# Altium schematic sheet INF_Quadrotor_V1.0.SchDoc(INF_Quadrotor_V1.0)
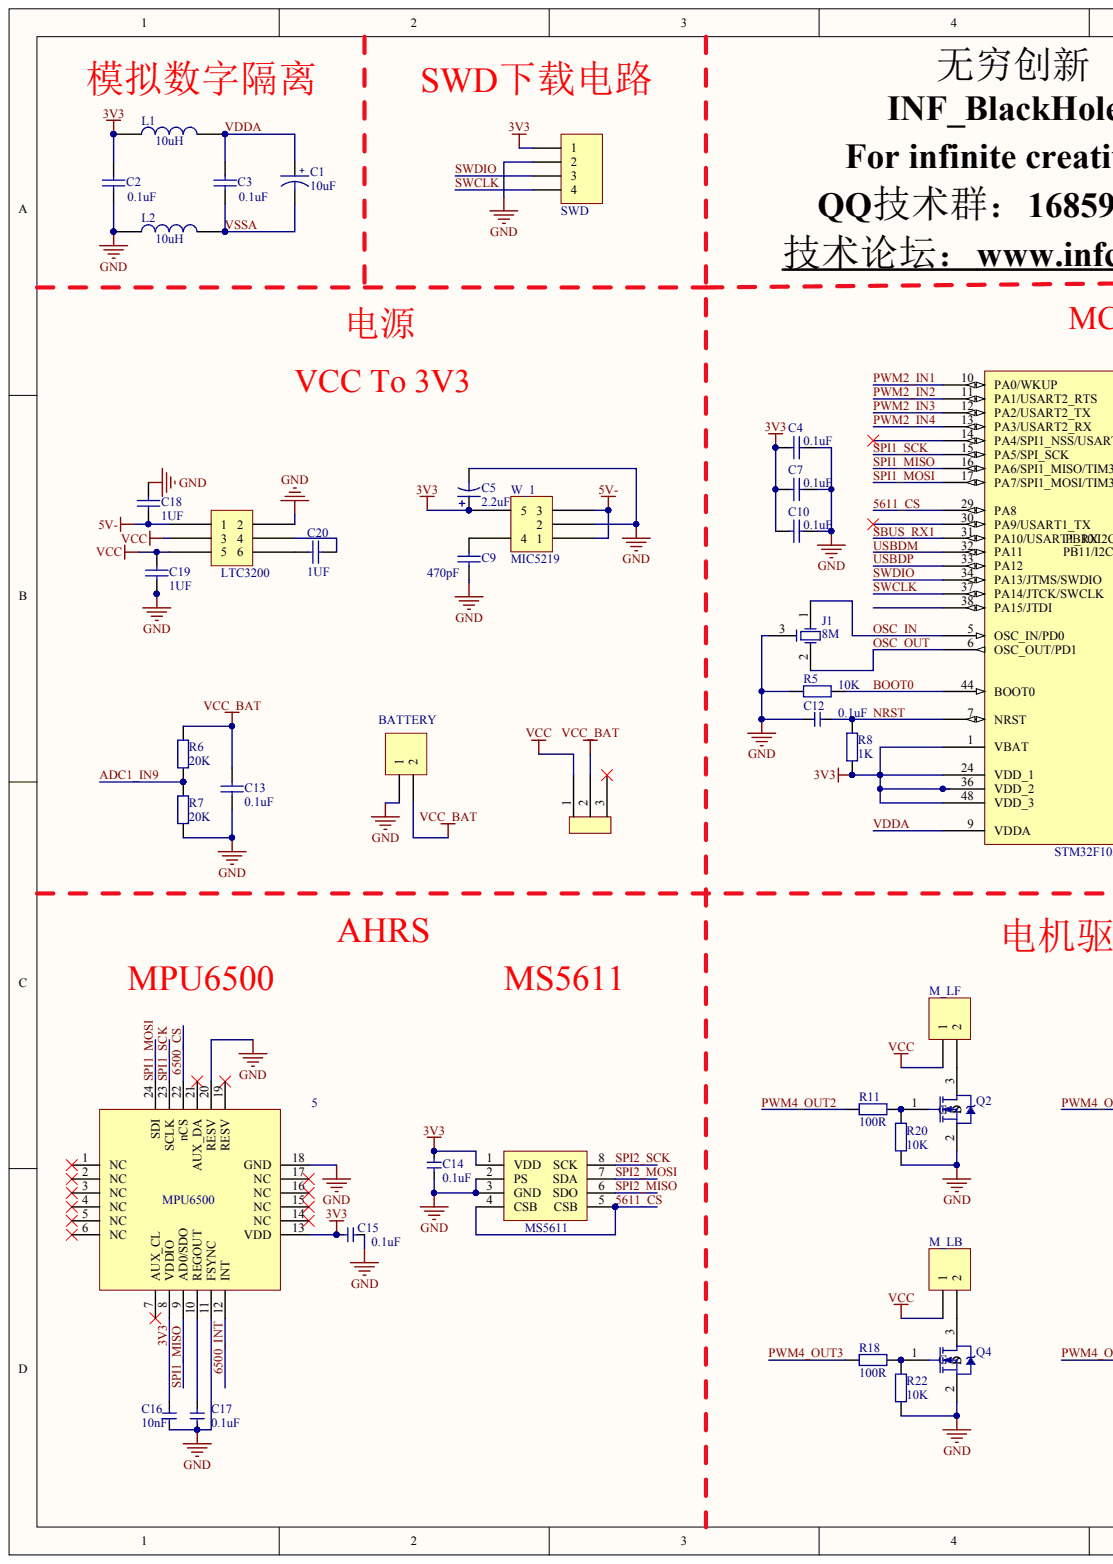
[diagram p0: INF_Quadrotor_V1.0.SchDoc, left]
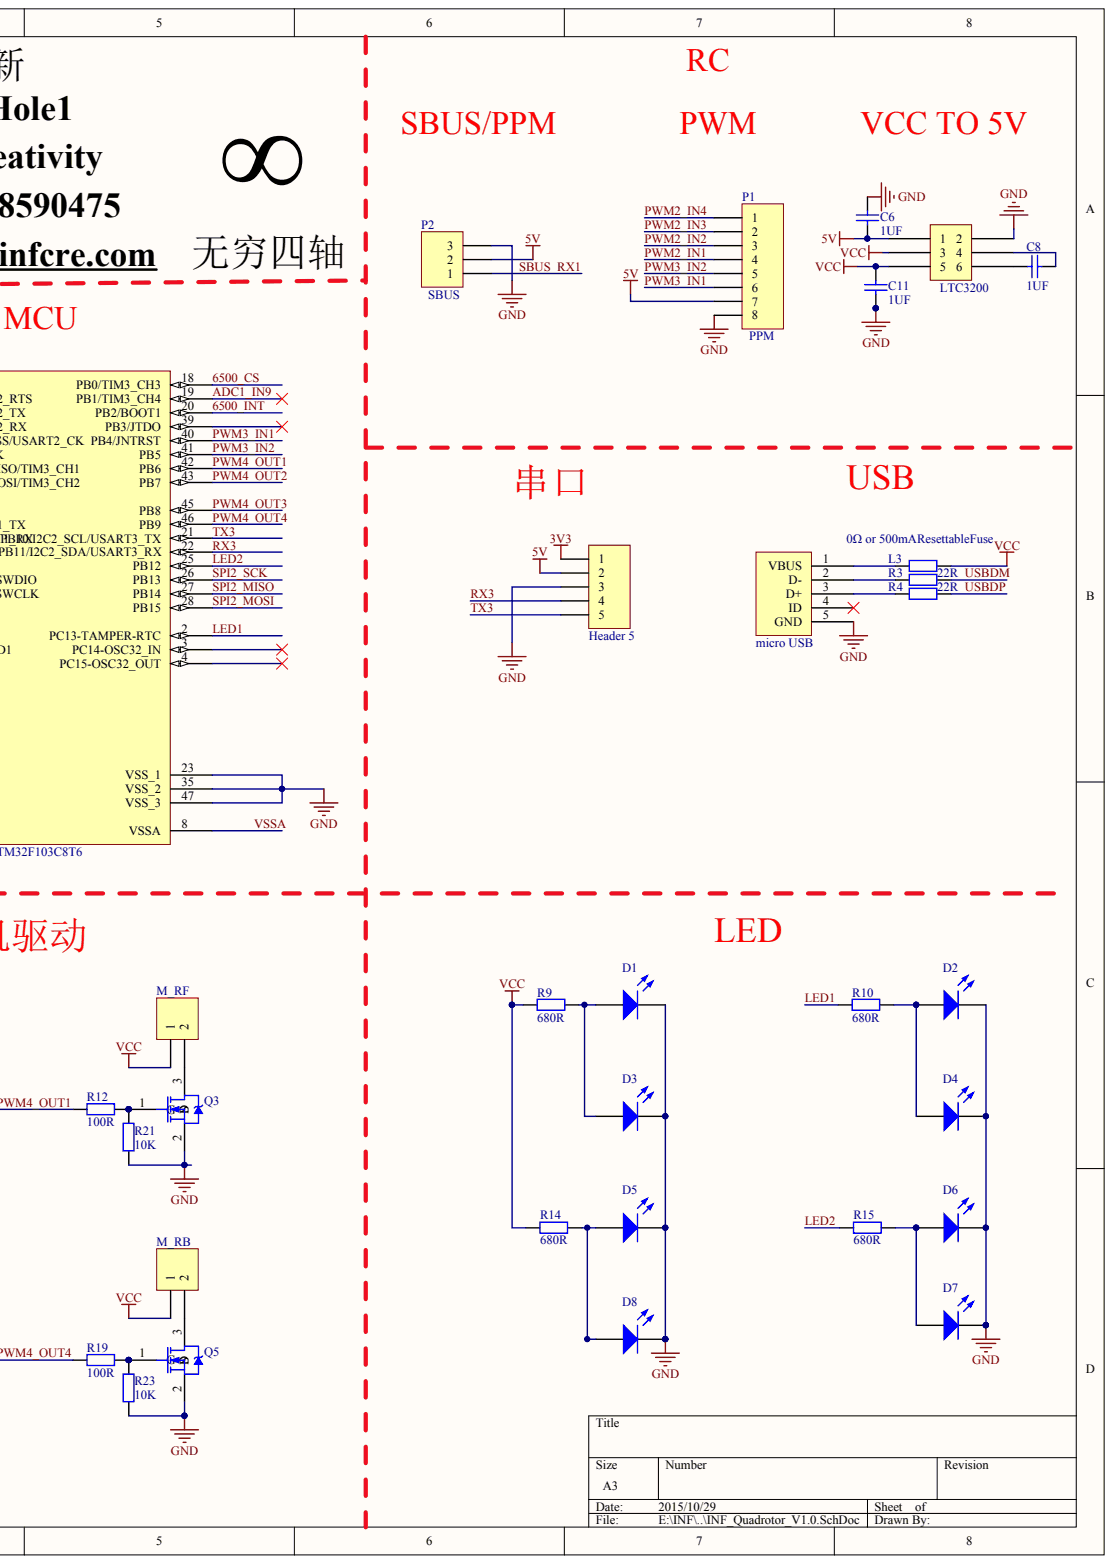
[diagram p1: INF_Quadrotor_V1.0.SchDoc, right]

## Parts
1  SWD
2  STM32F103C8T6
3  LTC3200
4  (no value)
5  MPU6500
C1  10uF
C2  value 0.1uF
C3  value 0.1uF
C4  value 0.1uF
C5  value 2.2uF  (hidden comment: Cap Pol1)
C6  value 1UF  (hidden comment: Cap)
C7  value 0.1uF
C8  value 1UF  (hidden comment: Cap)
C9  value 470pF  (hidden comment: Cap)
C10  value 0.1uF
C11  value 1UF  (hidden comment: Cap)
C12  value 0.1uF  (hidden comment: Cap_0402)
C13  value 0.1uF  (hidden comment: Cap)
C14  value 0.1uF  (hidden comment: Cap_0402)
C15  value 0.1uF  (hidden comment: Cap_0402)
C16  value 10nF  (hidden comment: 1608[0603])
C17  value 0.1uF  (hidden comment: Cap_0402)
C18  value 1UF  (hidden comment: Cap)
C19  value 1UF  (hidden comment: Cap)
C20  value 1UF  (hidden comment: Cap)
D1  LED2  (value not drawn)
D2  LED2  (value not drawn)
D3  LED2  (value not drawn)
D4  LED2  (value not drawn)
D5  LED2  (value not drawn)
D6  LED2  (value not drawn)
D7  LED2  (value not drawn)
D8  LED2  (value not drawn)
J1  8M
L1  10uH
L2  10uH
L3  value 0Ω or 500mAResettableFuse  (hidden comment: Res2)
M_LB  MHDR1X2  (value not drawn)
M_LF  MHDR1X2  (value not drawn)
M_RB  MHDR1X2  (value not drawn)
M_RF  MHDR1X2  (value not drawn)
MS1  MS5611
P1  PPM
P2  SBUS
P4  BATTERY
P?  Header 5
Q2  IRLML2502  (value not drawn)
Q3  IRLML2502  (value not drawn)
Q4  IRLML2502  (value not drawn)
Q5  IRLML2502  (value not drawn)
R3  value 22R  (hidden comment: Res2)
R4  value 22R  (hidden comment: Res2)
R5  value 10K  (hidden comment: Res2)
R6  value 20K  (hidden comment: Res2)
R7  value 20K  (hidden comment: Res2)
R8  value 1K  (hidden comment: Res2)
R9  value 680R  (hidden comment: Res2)
R10  value 680R  (hidden comment: Res2)
R11  value 100R  (hidden comment: Res2)
R12  value 100R  (hidden comment: Res2)
R14  value 680R  (hidden comment: Res2)
R15  value 680R  (hidden comment: Res2)
R18  value 100R  (hidden comment: Res2)
R19  value 100R  (hidden comment: Res2)
R20  value 10K  (hidden comment: Res2)
R21  value 10K  (hidden comment: Res2)
R22  value 10K  (hidden comment: Res2)
R23  value 10K  (hidden comment: Res2)
U1  micro USB
W_1  MIC5219
  LTC3200

## Nets
5V: 3.1 C6.1 P1.7 P2.2 P?.2
5V-: C18.1 W_1.1 W_1.3 .1
GND: 1.2 2.23(VSS_1) 2.35(VSS_2) 2.47(VSS_3) 3.2 5.11(FSYNC) 5.18(GND) 5.20(RESV) C2.1 C4.1 C5.2 C6.2 C7.1 C9.1 C10.1 C11.2 C12.1 C13.1 C14.2 C15.1 C16.1 C17.1 C18.2 C19.2 D1.2 D2.2 D3.2 D4.2 D5.2 D6.2 D7.2 D8.2 J1.3 L2.1 MS1.2(PS) MS1.3(GND) P1.8 P2.3 P4.1 P?.3 Q2.2 Q3.2 Q4.2 Q5.2 R5.1 R7.1 R20.1 R21.1 R22.1 R23.1 U1.5(GND) W_1.2 .2
Net_4: C20.2 .4
Net_6: C20.1 .6
VCC: 3.3 3.5 4.1 C11.1 C19.1 L3.1 M_LB.1 M_LF.1 M_RB.1 M_RF.1 R9.1 R14.1 .3 .5
VCC_BAT: 4.2 C13.2 P4.2 R6.1
3V3: 1.1 2.1(VBAT) 2.24(VDD_1) 2.36(VDD_2) 2.48(VDD_3) 5.8(VDDIO) 5.13(VDD) C2.2 C4.2 C5.1 C7.2 C10.2 C14.1 C15.2 C16.2 L1.1 MS1.1(VDD) P?.1 R8.1 W_1.5
5611_CS: 2.29(PA8) MS1.4(CSB) MS1.5(CSB)
6500_CS: 2.18(PB0/TIM3_CH3) 5.22(nCS)
6500_INT: 2.20(PB2/BOOT1) 5.12(INT)
ADC1_IN9: 2.19(PB1/TIM3_CH4) R6.2 R7.2
BOOT0: 2.44(BOOT0) R5.2
LED1: 2.2(PC13-TAMPER-RTC) R10.1
LED2: 2.25(PB12) R15.1
NRST: 2.7(NRST) C12.2 R8.2
OSC_IN: 2.5(OSC_IN/PD0) J1.1
OSC_OUT: 2.6(OSC_OUT/PD1) J1.2
PWM2_IN1: 2.10(PA0/WKUP) P1.4
PWM2_IN2: 2.11(PA1/USART2_RTS) P1.3
PWM2_IN3: 2.12(PA2/USART2_TX) P1.2
PWM2_IN4: 2.13(PA3/USART2_RX) P1.1
PWM3_IN1: 2.40(PB4/JNTRST) P1.6
PWM3_IN2: 2.41(PB5) P1.5
PWM4_OUT1: 2.42(PB6) R12.1
PWM4_OUT2: 2.43(PB7) R11.1
PWM4_OUT3: 2.45(PB8) R18.1
PWM4_OUT4: 2.46(PB9) R19.1
RX3: 2.22(PB11/I2C2_SDA/USART3_RX) P?.4
SBUS_RX1: 2.31(PA10/USART1_RX) P2.1
SPI1_MISO: 2.16(PA6/SPI1_MISO/TIM3_CH1) 5.9(AD0/SDO)
SPI1_MOSI: 2.17(PA7/SPI1_MOSI/TIM3_CH2) 5.24(SDI)
SPI1_SCK: 2.15(PA5/SPI_SCK) 5.23(SCLK)
SPI2_MISO: 2.27(PB14) MS1.6(SDO)
SPI2_MOSI: 2.28(PB15) MS1.7(SDA)
SPI2_SCK: 2.26(PB13) MS1.8(SCK)
SWCLK: 1.4 2.37(PA14/JTCK/SWCLK)
SWDIO: 1.3 2.34(PA13/JTMS/SWDIO)
TX3: 2.21(PB10/I2C2_SCL/USART3_TX) P?.5
USBDM: 2.32(PA11) R3.2
USBDP: 2.33(PA12) R4.2
VDDA: 2.9(VDDA) C1.1 C3.2 L1.2
VSSA: 2.8(VSSA) C1.2 C3.1 L2.2
Net3_4: 3.4 C8.2
Net3_6: 3.6 C8.1
Net5_10: 5.10(REGOUT) C17.2
NetC9_2: C9.2 W_1.4
NetD1_1: D1.1 D3.1 R9.2
NetD2_1: D2.1 D4.1 R10.2
NetD5_1: D5.1 D8.1 R14.2
NetD6_1: D6.1 D7.1 R15.2
NetL3_2: L3.2 U1.1(VBUS)
NetM_LB_2: M_LB.2 Q4.3
NetM_LF_2: M_LF.2 Q2.3
NetM_RB_2: M_RB.2 Q5.3
NetM_RF_2: M_RF.2 Q3.3
NetQ2_1: Q2.1 R11.2 R20.2
NetQ3_1: Q3.1 R12.2 R21.2
NetQ4_1: Q4.1 R18.2 R22.2
NetQ5_1: Q5.1 R19.2 R23.2
NetR3_1: R3.1 U1.2(D-)
NetR4_1: R4.1 U1.3(D+)

Not connected: 2 3(PC14-OSC32_IN) 4(PC15-OSC32_OUT) 14(PA4/SPI1_NSS/USART2_CK) 30(PA9/USART1_TX) 38(PA15/JTDI) 39(PB3/JTDO); 4 3; 5 1(NC) 2(NC) 3(NC) 4(NC) 5(NC) 6(NC) 7(AUX_CL) 14(NC) 15(NC) 16(NC) 17(NC) 19(RESV) 21(AUX_DA); U1 4(ID)

## Library data of the parts
L3 = (no symbol name): Value: 0Ω or 500mAResettableFuse; Published: 8-Jun-2000; LatestRevisionDate: 17-Jul-2002; LatestRevisionNote: Re-released for DXP Platform.; PackageReference: AXIAL-0.4; Publisher: Altium Limited
Q2-Q5 = (no symbol name): Note: Pin 2 is electrically connected to pin 4.; Published: 8-Jun-2000; LatestRevisionDate: 17-Jul-2002; LatestRevisionNote: Re-released for DXP Platform.; DatasheetDocument: PD-9.814; PackageReference: TO-220AB; Code_JEDEC: TO-220-AB; ComponentLink1Description: Manufacturer Link; ComponentLink1URL: http://www.irf.com/; Publisher: Altium Limited
1-5 C1-C20 D1-D8 J1 L1 L2 M_LB M_LF M_RB M_RF MS1 P1 P2 P4 P? R3-R12 R14 R15 R18-R23 U1 W_1  = (no symbol name)
  1 M_LB M_LF M_RB M_RF P1 P2 P4 P?: LatestRevisionDate: 17-Jul-2002; LatestRevisionNote: Re-released for DXP Platform.
  2: Published: 30-May-2008; DatasheetVersion: rev6, Mar-2008; PackageVersion: Mar-2008; PackageReference: LQFP48; PackageDescription: 48-Pin Low-Profile Quad Flat Pack, 7 x 7 mm Body, 0.5 mm Pitch (LQFP48); ComponentLink1Description: Manufacturer Link; ComponentLink1URL: www.st.com/stm32; ComponentLink2Description: Datasheet; ComponentLink2URL: http://www.st.com/stonline/products/literature/ds/13587.pdf
  C2-C4 C6-C11 C13 C18-C20: Published: 8-Jun-2000; LatestRevisionDate: 17-Jul-2002; LatestRevisionNote: Re-released for DXP Platform.; PackageReference: RAD-0.3
  C5: Published: 8-Jun-2000; LatestRevisionDate: 17-Jul-2002; LatestRevisionNote: Re-released for DXP Platform.; PackageReference: RB7.6-15
  D1-D8: Published: 8-Jun-2000; LatestRevisionDate: 17-Jul-2002; LatestRevisionNote: Re-released for DXP Platform.; PackageDocument: Feb-2002; PackageReference: 3.2X1.6X1.1
  R3-R12 R14 R15 R18-R23: Published: 8-Jun-2000; LatestRevisionDate: 17-Jul-2002; LatestRevisionNote: Re-released for DXP Platform.; PackageReference: AXIAL-0.4
  1 2 C2-C11 C13 C18-C20 D1-D8 M_LB M_LF M_RB M_RF P1 P2 P4 P? R3-R12 R14 R15 R18-R23: Publisher: Altium Limited
